# Altium schematic sheet motherboard.SchDoc(motherboard)
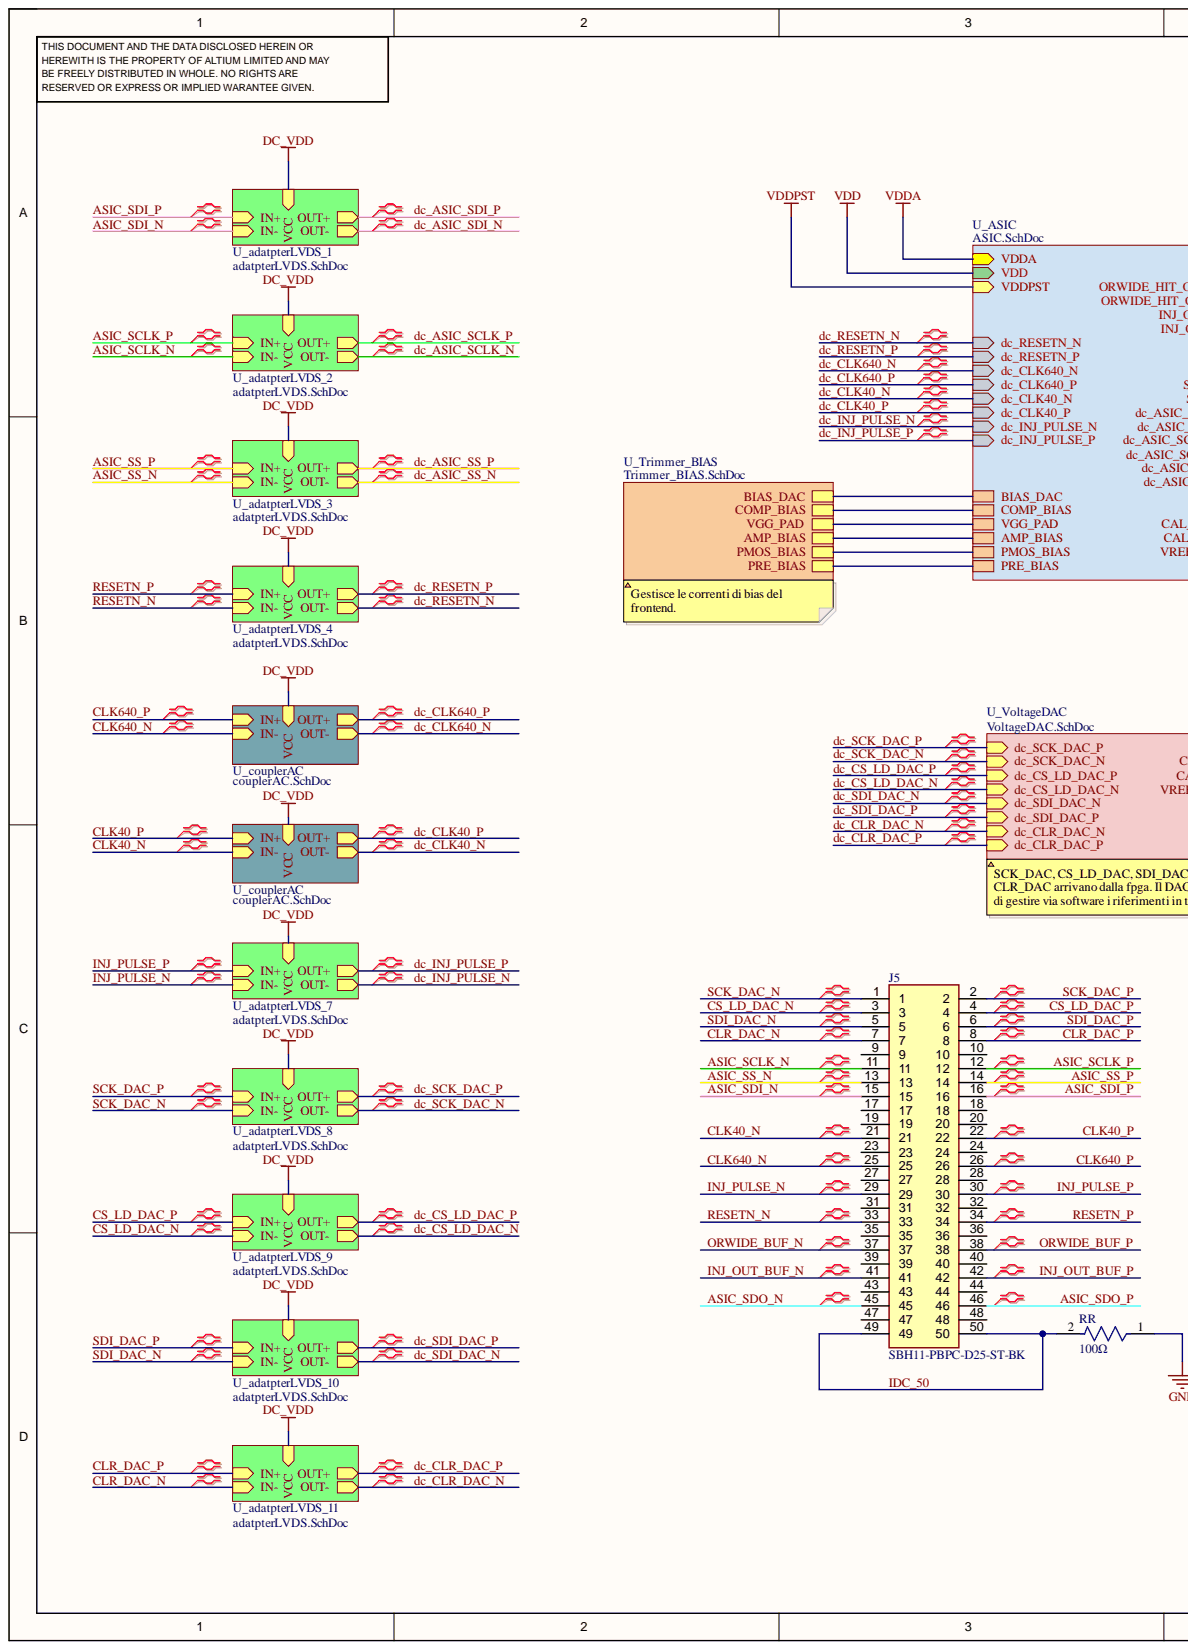
[diagram p0: motherboard.SchDoc, left]
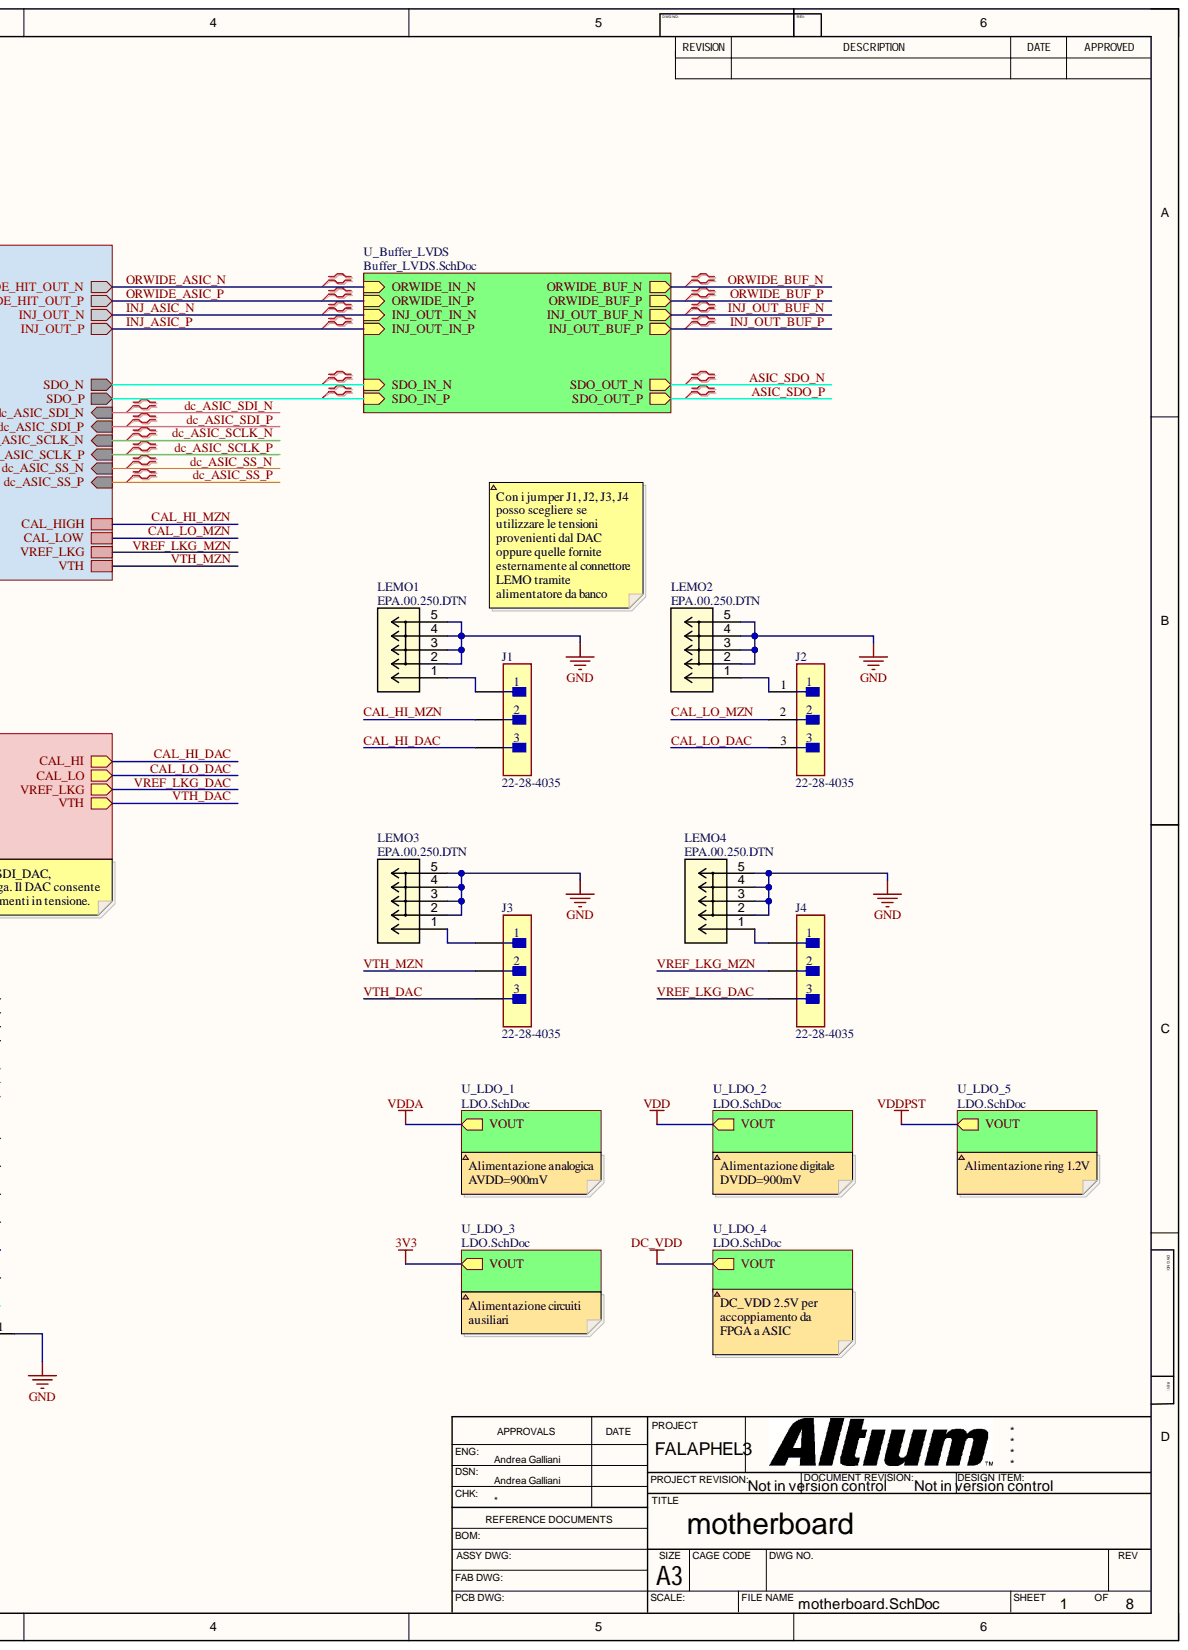
[diagram p1: motherboard.SchDoc, right]

## Parts
J1  22-28-4035  [STP3X1_254mm]
J2  22-28-4035  [STP3X1_254mm]
J3  22-28-4035  [STP3X1_254mm]
J4  22-28-4035  [STP3X1_254mm]
J5  SBH11-PBPC-D25-ST-BK  [SULLINS_SBH11-XXXC-D25-ST]
LEMO1  EPA.00.250.DTN  [LEMO_EPA.00.250.DTN]
LEMO2  EPA.00.250.DTN  [LEMO_EPA.00.250.DTN]
LEMO3  EPA.00.250.DTN  [LEMO_EPA.00.250.DTN]
LEMO4  EPA.00.250.DTN  [LEMO_EPA.00.250.DTN]
RR  100Ω  [0603R]

## Nets
GND: LEMO1.2 LEMO1.3 LEMO1.4 LEMO1.5 LEMO2.2 LEMO2.3 LEMO2.4 LEMO2.5 LEMO3.2 LEMO3.3 LEMO3.4 LEMO3.5 LEMO4.2 LEMO4.3 LEMO4.4 LEMO4.5 RR.1
ASIC_SCLK_N: J5.11
ASIC_SCLK_P: J5.12
ASIC_SDI_N: J5.15
ASIC_SDI_P: J5.16
ASIC_SDO_N: J5.45
ASIC_SDO_P: J5.46
ASIC_SS_N: J5.13
ASIC_SS_P: J5.14
CAL_HI_DAC: J1.3
CAL_HI_MZN: J1.2
CAL_LO_DAC: J2.3
CAL_LO_MZN: J2.2
CLK40_N: J5.21
CLK40_P: J5.22
CLK640_N: J5.25
CLK640_P: J5.26
CLR_DAC_N: J5.7
CLR_DAC_P: J5.8
CS_LD_DAC_N: J5.3
CS_LD_DAC_P: J5.4
IDC_50: J5.49 J5.50 RR.2
INJ_OUT_BUF_N: J5.41
INJ_OUT_BUF_P: J5.42
INJ_PULSE_N: J5.29
INJ_PULSE_P: J5.30
ORWIDE_BUF_N: J5.37
ORWIDE_BUF_P: J5.38
RESETN_N: J5.33
RESETN_P: J5.34
SCK_DAC_N: J5.1
SCK_DAC_P: J5.2
SDI_DAC_N: J5.5
SDI_DAC_P: J5.6
VREF_LKG_DAC: J4.3
VREF_LKG_MZN: J4.2
VTH_DAC: J3.3
VTH_MZN: J3.2
NetJ1_1: J1.1 LEMO1.1
NetJ2_1: J2.1 LEMO2.1
NetJ3_1: J3.1 LEMO3.1
NetJ4_1: J4.1 LEMO4.1
NetJ5_9: J5.9
NetJ5_10: J5.10
NetJ5_17: J5.17
NetJ5_18: J5.18
NetJ5_19: J5.19
NetJ5_20: J5.20
NetJ5_23: J5.23
NetJ5_24: J5.24
NetJ5_27: J5.27
NetJ5_28: J5.28
NetJ5_31: J5.31
NetJ5_32: J5.32
NetJ5_35: J5.35
NetJ5_36: J5.36
NetJ5_39: J5.39
NetJ5_40: J5.40
NetJ5_43: J5.43
NetJ5_44: J5.44
NetJ5_47: J5.47
NetJ5_48: J5.48
3V3:
AMP_BIAS:
BIAS_DAC:
COMP_BIAS:
dc_ASIC_SCLK_N:
dc_ASIC_SCLK_P:
dc_ASIC_SDI_N:
dc_ASIC_SDI_P:
dc_ASIC_SS_N:
dc_ASIC_SS_P:
dc_CLK40_N:
dc_CLK40_P:
dc_CLK640_N:
dc_CLK640_P:
dc_CLR_DAC_N:
dc_CLR_DAC_P:
dc_CS_LD_DAC_N:
dc_CS_LD_DAC_P:
dc_INJ_PULSE_N:
dc_INJ_PULSE_P:
dc_RESETN_N:
dc_RESETN_P:
dc_SCK_DAC_N:
dc_SCK_DAC_P:
dc_SDI_DAC_N:
dc_SDI_DAC_P:
DC_VDD:
INJ_ASIC_N:
INJ_ASIC_P:
ORWIDE_ASIC_N:
ORWIDE_ASIC_P:
PMOS_BIAS:
PRE_BIAS:
SDO_IN_N:
SDO_IN_P:
VDD:
VDDA:
VDDPST:
VGG_PAD:

## Library data of the parts
J1-J4 = CMP-00257-00247547-1: 22-28-4035 BOARD-BOARD Connector HEADER, 3WAY, 1ROW; Series: KK; Pitch Spacing: 2.54mm; No. of Rows: 1;; Manufacturer: Molex; Voltage Rating: 250V; Component Kind: Standard; Library Name: Altium Content Vault; Mount: THT; Package: STP3X1_254mm; Part Number: 22-28-4035
J5 = SBH11-PBPC-D25-ST-BK: SBH11-PBPC-D25-ST-BK BOARD-BOARD Connector HEADER, 50WAY, 1ROW; Series: SBH11; Pitch Spacing: 2.54mm; No. of Rows: 1;; Manufacturer: Sullins; Component Kind: Standard; Mount: THT; Package: SULLINS_SBH11-PBPC-D25-ST-BK; Part Number: SBH11-PBPC-D25-ST-BK
LEMO1-LEMO4 = EPA.00.250.DTN: Connector Coaxial SOCKET 50Ω; Manufacturer: LEMO; Component Kind: Standard; Library Name: EPAP00P250PDTN.IntLib; Mount: THT; Package: LEMO_EPA.00.250.DTN; Part Number: EPA.00.250.DTN
RR = CMP-2100-03663-2: Thick Film Chip Resistors 0603 100Ω 0.333W 1% 200ppm/°C; Manufacturer: YAGEO; Part Number: RC0603FR-07100RL; Power Rating: 100mW; Voltage Rating: 50V; Component Kind: Standard; Library Name: Altium Content Vault; Mount: SMD; Package: 0603; Tolerance: 1%; Value: 100Ω
